# Altium schematic sheet Sheet1.SchDoc
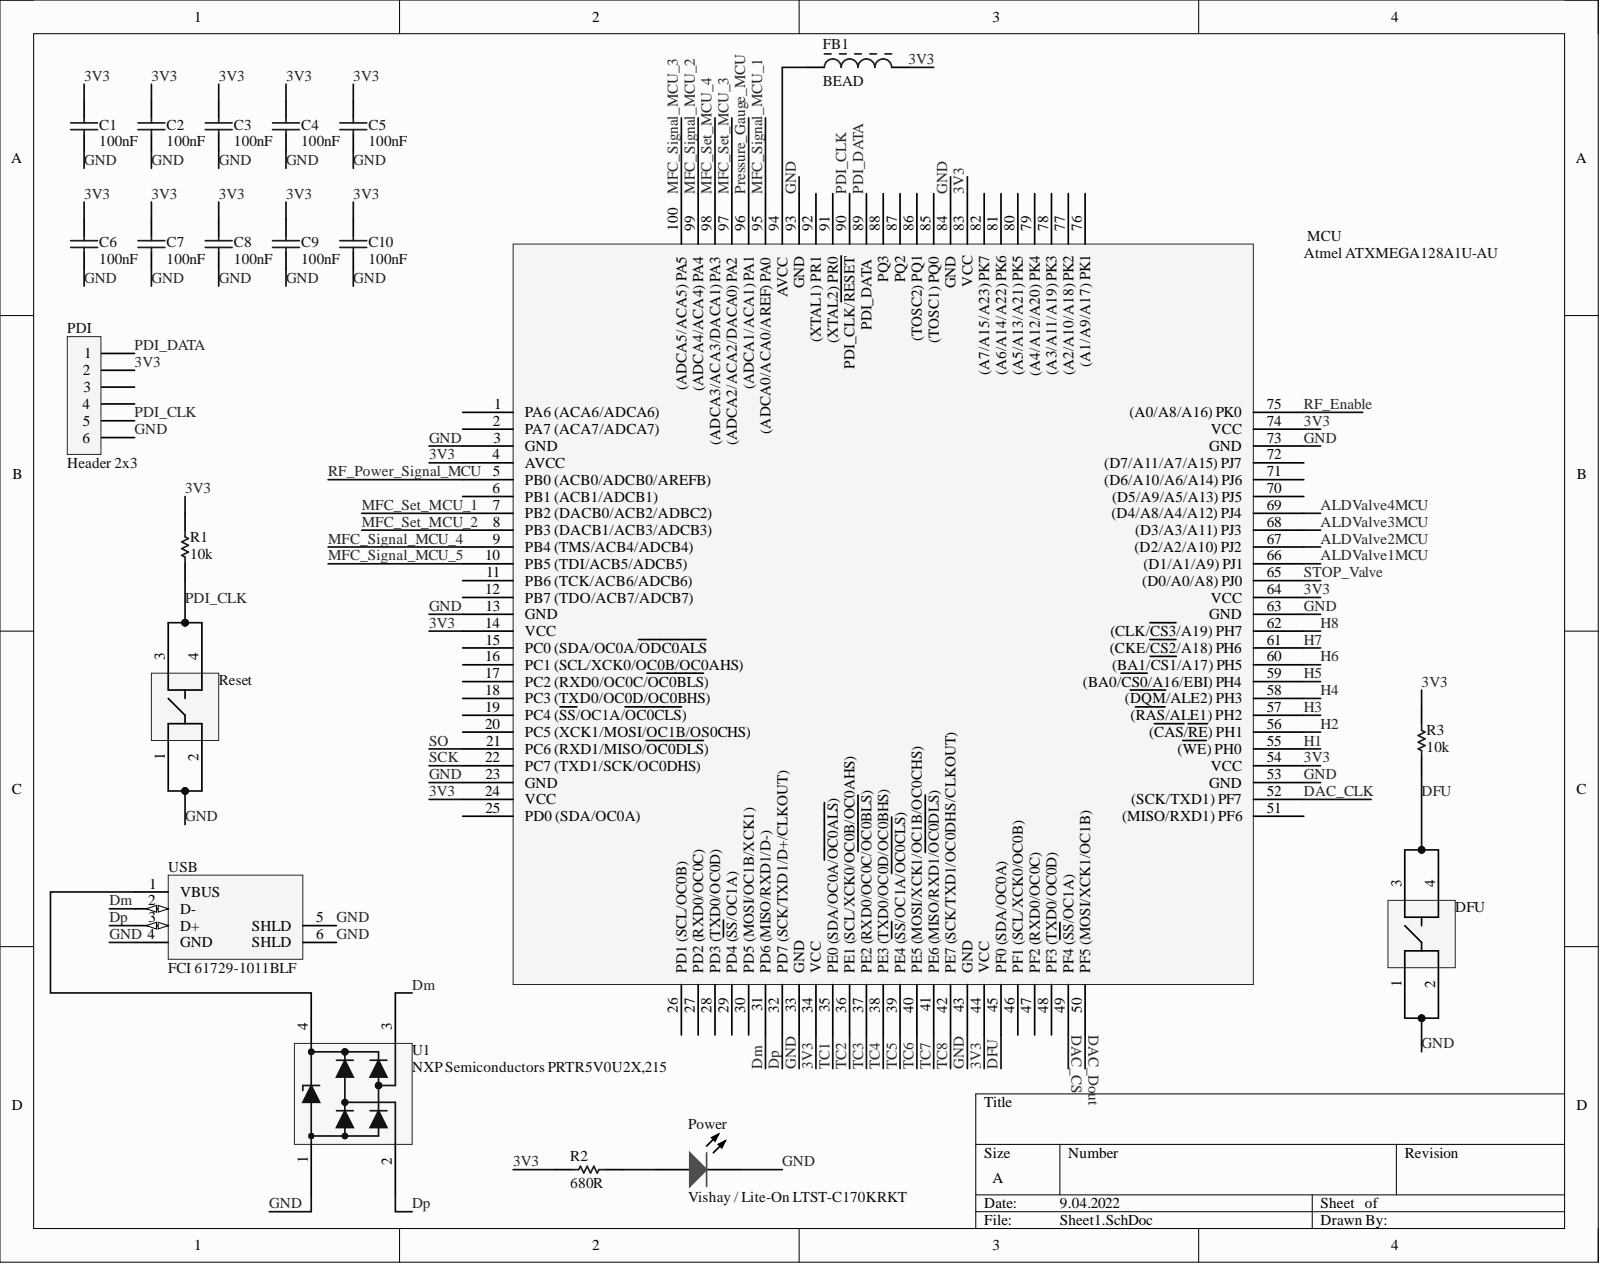
[diagram p0: Sheet1.SchDoc]

## Parts
C1  100nF  [CAPC1005X56X25LL10T15]
C2  100nF  [CAPC1005X56X25LL10T15]
C3  100nF  [CAPC1005X56X25LL10T15]
C4  100nF  [CAPC1005X56X25LL10T15]
C5  100nF  [CAPC1005X56X25LL10T15]
C6  100nF  [CAPC1005X56X25LL10T15]
C7  100nF  [CAPC1005X56X25LL10T15]
C8  100nF  [CAPC1005X56X25LL10T15]
C9  100nF  [CAPC1005X56X25LL10T15]
C10  100nF  [CAPC1005X56X25LL10T15]
DFU  (no value)  [PCB-1274972-1]
FB1  BEAD  [MURA-BLM15-CHIP-2_V]
MCU  Atmel ATXMEGA128A1U-AU  [TSQFP50P1600X1600X120-100N]
PDI  Header 2x3  [PCB-642643-1]
Power  Vishay / Lite-On LTST-C170KRKT  [PCB-1544870-1]
R1  10k  [PCB-xna89c7rj2sv02b80bkg-1]
R2  680R  [PCB-xna89c7rj2sv02b80bkg-1]
R3  10k  [PCB-xna89c7rj2sv02b80bkg-1]
Reset  (no value)  [PCB-1274972-1]
U1  NXP Semiconductors PRTR5V0U2X,215  [PCB-282990-1]
USB  FCI 61729-1011BLF  [FCI-61729-1011BLF]

## Nets
3V3: C1.2 C2.2 C3.2 C4.2 C5.2 C6.2 C7.2 C8.2 C9.2 C10.2 FB1.2 MCU.4(AVCC) MCU.14(VCC) MCU.24(VCC) MCU.34(VCC) MCU.44(VCC) MCU.54(VCC) MCU.64(VCC) MCU.74(VCC) MCU.83(VCC) PDI.2 R1.2 R2.2 R3.2
ALDValve1MCU: MCU.66((D1/A1/A9)_PJ1)
ALDValve2MCU: MCU.67((D2/A2/A10)_PJ2)
ALDValve3MCU: MCU.68((D3/A3/A11)_PJ3)
ALDValve4MCU: MCU.69((D4/A8/A4/A12)_PJ4)
DAC_CLK: MCU.52((SCK/TXD1)_PF7)
DAC_CS: MCU.49(PF4_(SS/OC1A))
DAC_Dout: MCU.50(PF5_(MOSI/XCK1/OC1B))
DFU: DFU.3 DFU.4 MCU.45(PF0_(SDA/OC0A)) R3.1
Dm: MCU.31(PD6_(MISO/RXD1/D-)) U1.3 USB.2(D-)
Dp: MCU.32(PD7_(SCK/TXD1/D+/CLKOUT)) U1.2 USB.3(D+)
GND: C1.1 C2.1 C3.1 C4.1 C5.1 C6.1 C7.1 C8.1 C9.1 C10.1 DFU.1 DFU.2 MCU.3(GND) MCU.13(GND) MCU.23(GND) MCU.33(GND) MCU.43(GND) MCU.53(GND) MCU.63(GND) MCU.73(GND) MCU.84(GND) MCU.93(GND) PDI.6 Power.2 Reset.1 Reset.2 U1.1 USB.4(GND) USB.5(SHLD) USB.6(SHLD)
H1: MCU.55((WE)_PH0)
H2: MCU.56((CAS/RE)_PH1)
H3: MCU.57((RAS/ALE1)_PH2)
H4: MCU.58((DQM/ALE2)_PH3)
H5: MCU.59((BA0/CS0/A16/EBI)_PH4)
H6: MCU.60((BA1/CS1/A17)_PH5)
H7: MCU.61((CKE/CS2/A18)_PH6)
H8: MCU.62((CLK/CS3/A19)_PH7)
MFC_Set_MCU_1: MCU.7(PB2_(DACB0/ACB2/ADBC2))
MFC_Set_MCU_2: MCU.8(PB3_(DACB1/ACB3/ADCB3))
MFC_Set_MCU_3: MCU.97((ADCA2/ACA2/DACA0)_PA2)
MFC_Set_MCU_4: MCU.98((ADCA3/ACA3/DACA1)_PA3)
MFC_Signal_MCU_1: MCU.95((ADCA0/ACA0/AREF)_PA0)
MFC_Signal_MCU_2: MCU.99((ADCA4/ACA4)_PA4)
MFC_Signal_MCU_3: MCU.100((ADCA5/ACA5)_PA5)
MFC_Signal_MCU_4: MCU.9(PB4_(TMS/ACB4/ADCB4))
MFC_Signal_MCU_5: MCU.10(PB5_(TDI/ACB5/ADCB5))
PDI_CLK: MCU.90(PDI_CLK/RESET) PDI.5 R1.1 Reset.3 Reset.4
PDI_DATA: MCU.89(PDI_DATA) PDI.1
Pressure_Gauge_MCU: MCU.96((ADCA1/ACA1)_PA1)
RF_Enable: MCU.75((A0/A8/A16)_PK0)
RF_Power_Signal_MCU: MCU.5(PB0_(ACB0/ADCB0/AREFB))
SCK: MCU.22(PC7_(TXD1/SCK/OC0DHS))
SO: MCU.21(PC6_(RXD1/MISO/OC0DLS))
STOP_Valve: MCU.65((D0/A0/A8)_PJ0)
TC1: MCU.35(PE0_(SDA/OC0A/OC0ALS))
TC2: MCU.36(PE1_(SCL/XCK0/OC0B/OC0AHS))
TC3: MCU.37(PE2_(RXD0/OC0C/OC0BLS))
TC4: MCU.38(PE3_(TXD0/OC0D/OC0BHS))
TC5: MCU.39(PE4_(SS/OC1A/OC0CLS))
TC6: MCU.40(PE5_(MOSI/XCK1/OC1B/OC0CHS))
TC7: MCU.41(PE6_(MISO/RXD1/OC0DLS))
TC8: MCU.42(PE7_(SCK/TXD1/OC0DHS/CLKOUT))
NetFB1_1: FB1.1 MCU.94(AVCC)
NetPower_1: Power.1 R2.1
NetU1_4: U1.4 USB.1(VBUS)

Not connected: MCU 1(PA6_(ACA6/ADCA6)) 2(PA7_(ACA7/ADCA7)) 6(PB1_(ACB1/ADCB1)) 11(PB6_(TCK/ACB6/ADCB6)) 12(PB7_(TDO/ACB7/ADCB7)) 15(PC0_(SDA/OC0A/ODC0ALS) 16(PC1_(SCL/XCK0/OC0B/OC0AHS)) 17(PC2_(RXD0/OC0C/OC0BLS)) 18(PC3_(TXD0/OC0D/OC0BHS)) 19(PC4_(SS/OC1A/OC0CLS)) 20(PC5_(XCK1/MOSI/OC1B/OS0CHS)) 25(PD0_(SDA/OC0A)) 26(PD1_(SCL/OC0B)) 27(PD2_(RXD0/OC0C)) 28(PD3_(TXD0/OC0D)) 29(PD4_(SS/OC1A)) 30(PD5_(MOSI/OC1B/XCK1)) 46(PF1_(SCL/XCK0/OC0B)) 47(PF2_(RXD0/OC0C)) 48(PF3_(TXD0/OC0D)) 51((MISO/RXD1)_PF6) 70((D5/A9/A5/A13)_PJ5) 71((D6/A10/A6/A14)_PJ6) 72((D7/A11/A7/A15)_PJ7) 76((A1/A9/A17)_PK1) 77((A2/A10/A18)_PK2) 78((A3/A11/A19)_PK3) 79((A4/A12/A20)_PK4) 80((A5/A13/A21)_PK5) 81((A6/A14/A22)_PK6) 82((A7/A15/A23)_PK7) 85((TOSC1)_PQ0) 86((TOSC2)_PQ1) 87(PQ2) 88(PQ3) 91((XTAL2)_PR0) 92((XTAL1)_PR1); PDI 3 4

## Library data of the parts
C1-C10 = CMP-10875562-2: Capacitor 0.1 uF 16 Volt Y5 Volt -20% To 80% Surface Mount 0402 Paper Tape and Reel; Capacitance: 1e-07 F; Capacitance Tolerance: +80/-20%; Dielectric Characteristic: Y5V; Dielectric Material: Ceramic Multilayer; Lead-Free Status: Lead Free; Voltage Rating (DC): 16.0 V; Insulation Resistance: 1e+11 Ω; Case/Package: 0402; Component Kind: Standard; Library Name: CommunityVault; Mounting Style: Surface Mount; Number of Pins: 2; Octopart Url: https://octopart.com/0402yg104zat2a-avx+interconnect+%2F+elco-38554; OctopartID: 7a36b33cc9caa5f1; Packaging: Cut Tape (CT); REACH SVHC Compliance: No SVHC; RoHS: Compliant; Size-Height: 0.00056 m; Size-Length: 0.001 m; Size-Thickness: 0.0008636 m; Size-Width: 0.0005 m
DFU Reset = CMP-1274972-2: SWITCH TACTILE SPST-NO 0.05A 24V; Contacts Type: SPST; ELV Compliant: Compliant; Lead-Free Status: Lead Free; Component Kind: Standard; Contact Current Rating: 0.05 A; Contact Material: Copper Alloy; Contact Plating: Silver; Library Name: CommunityVault; Lifecycle Status: Active; Mounting Style: Surface Mount; Octopart Url: https://octopart.com/fsm4jsmatr-te+connectivity+%2F+alcoswitch-39752200; OctopartID: 00c5cb91b7abdf02; Packaging: Tape & Reel (TR); REACH SVHC Compliance: No SVHC; RoHS: Compliant
FB1 = CMP-886469-3: Chip Ferrite Bead for High-speed Signal Lines, 220 Ohm, 200 mA, -55 to 125 degC, 1 x 0.5 x 0.55 mm SMD, Tape and Reel; Lead-Free Status: Lead Free; Number of Circuits: 1; Resistance: 220.0 Ω; Shielding: No; Case/Package: 0402; Component Kind: Standard; Library Name: CommunityVault; Mounting Style: Surface Mount; Number of Pins: 2; Octopart Url: https://octopart.com/blm15bb221sn1d-murata-755249; OctopartID: e7c42ec544933803; Packaging: Cut Tape (CT); REACH SVHC Compliance: No SVHC; RoHS: Compliant; Size-Length: 0.001 m; Size-Width: 0.0005 m
MCU = CMP-30d7fb741d15ef10-1: case, size, pin, supply, series, max, flash, controller, Microcontrollers, tqfp, s, Microprocessors, min, memory, Sem...; Clock Speed: 32000000.0 Hz; RAM Memory Size: 8192 B; Supply Voltage (DC): V; Case/Package: 100A; Component Kind: Standard; Library Name: CommunityVault; Manufacturer Part Number: ATXMEGA128A1U-AU; Mounting Style: Surface Mount; Number of Pins: 100; Octopart URL: http://octopart.com/atxmega128a1u-au-atmel-44632228; OctopartID: 30d7fb741d15ef10; REACH SVHC Compliance: No SVHC; RoHS: Compliant
PDI = CMP-642643-3: Headers & Wire Housings 2.54MM CGRIDIII HDR 6P V DR SHRD AU-E; Contact Resistance: 0.02 Ω; Current Rating: 3.0 A; Gender: Male; Glow Wire Compliant: Non-Compliant; Lead-Free Status: Lead Free; Number of Circuits: 6; Pin Pitch: 0.00254 m; Voltage Rating (AC): 350.0 V; Insulation Resistance: 5000000000.0 Ω; Color: Black; Component Kind: Standard; Contact Material: Copper; Contact Plating: Gold; Halogen Free Status: Not Low Halogen; Housing Color: Black; Housing Material: Polyester; Library Name: CommunityVault; Lifecycle Status: Active; Material: Polyester; Number of Contacts: 6; Number of Positions: 6; Number of Rows: 2; Octopart Url: https://octopart.com/90130-1206-molex-655590; OctopartID: 4165886564e59ea2; Orientation: Vertical; Packaging: Tray; REACH SVHC Compliance: No SVHC; RoHS: Compliant; Size-Height: 0.0109 m; Size-Length: 0.010338 m; Size-Width: 0.00975 m; Voltage Rating (DC): 350.0 V
Power = CMP-1544870-2: LED SUPER RED CLEAR 0805 SMD; Case/Package (SI): 2012; Forward Voltage: 2.0 V; Lead-Free Status: Lead Free; Lens Type: Non-Diffused; Luminous Intensity: 0.054 cd; Viewing Angle: 130 °; Wavelength: 6.39e-07 m; Case/Package: 0805; Color: Red; Component Kind: Standard; Library Name: CommunityVault; Octopart Url: https://octopart.com/ltst-c170krkt-lite-on-549878; OctopartID: 1d24d4265bb4c2e3; RoHS: Compliant; Size-Height: 0.0011 m; Size-Length: 0.002 m; Size-Width: 0.00125 m
R1-R3 = CMP-4716668-10: Res Thick Film 0603 10K Ohm 1% 0.1W(1/10W) ?100ppm/C SMD Automotive Paper T/R; Manufacturer Part Number: CRCW060310K0FKEA; Lead-Free Status: Lead Free; Temperature Coefficient: 100.0 ppm/°C; Voltage Rating (DC): 75.0 V; Case/Package: 0603; Component Kind: Standard; Library Name: CommunityVault; Mounting Style: Surface Mount; Octopart URL: http://octopart.com/crcw060310k0fkea-vishay-55388213; OctopartID: 4aec80ab47bf952b; Power Rating: 0.1 W; Resistance: 10k; Resistance Tolerance: ±1%; RoHS: Compliant
U1 = CMP-282990-2: TVS DIODE 5.5VWM SOT143B; Lead-Free Status: Lead Free; Component Kind: Standard; Library Name: CommunityVault; Lifecycle Status: Active; Mounting Style: Surface Mount; Number of Pins: 4; Octopart Url: https://octopart.com/prtr5v0u2x%2C215-nxp+semiconductors-9290867; OctopartID: d93310b4382606f4; RoHS: Compliant
USB = CMP-777751-2: USB RCPT B-TYPE R/A FULL BACK; Gender: Female; Lead-Free Status: Lead Free; Number of Contacts: 4; Component Kind: Standard; Contact Material: Copper Alloy; Contact Plating: Gold; Library Name: CommunityVault; Octopart Url: https://octopart.com/61729-1011blf-fci-39856787; OctopartID: cb14007ec4e114d3; Orientation: Right Angle; RoHS: Compliant
